# Altium schematic sheet S7CAS-PLEIADES-CARTE-MERE_P07_FPGA_0.SchDoc(S7CAS-PLEIADES-CARTE-MERE_P07_FPGA_0)
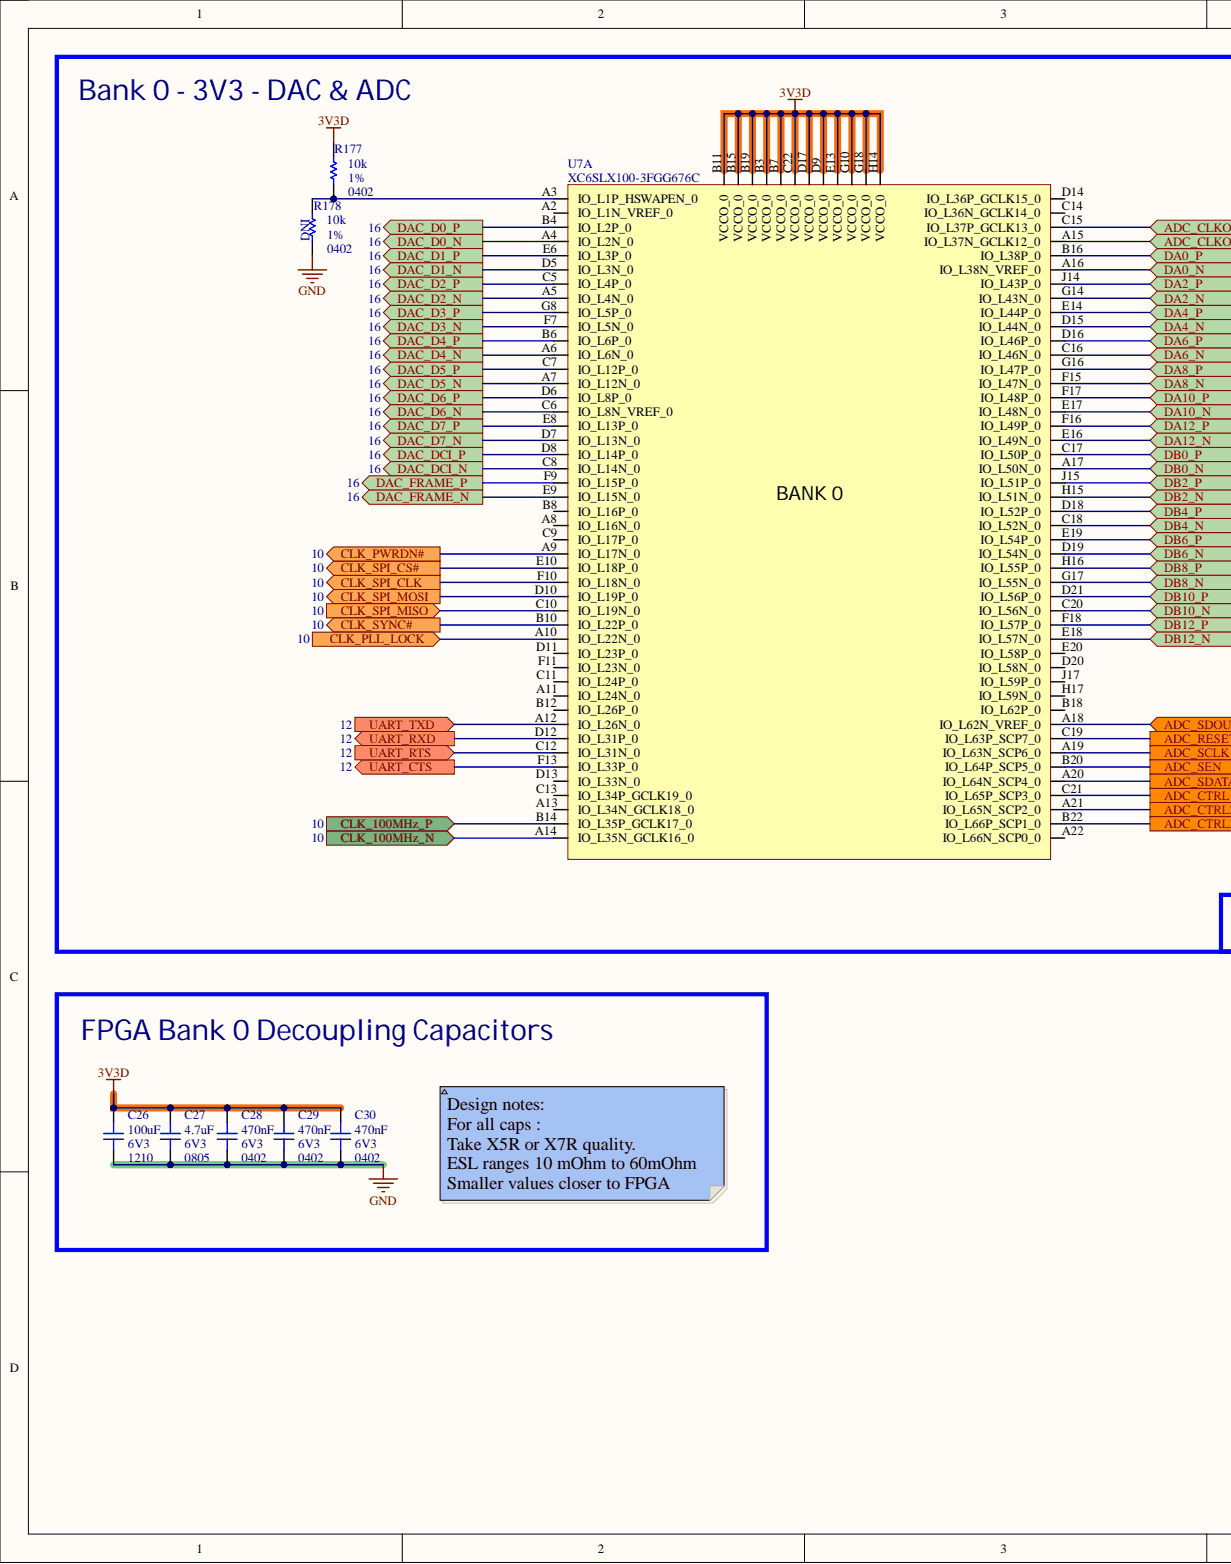
[diagram p0: S7CAS-PLEIADES-CARTE-MERE_P07_FPGA_0.SchDoc, left]
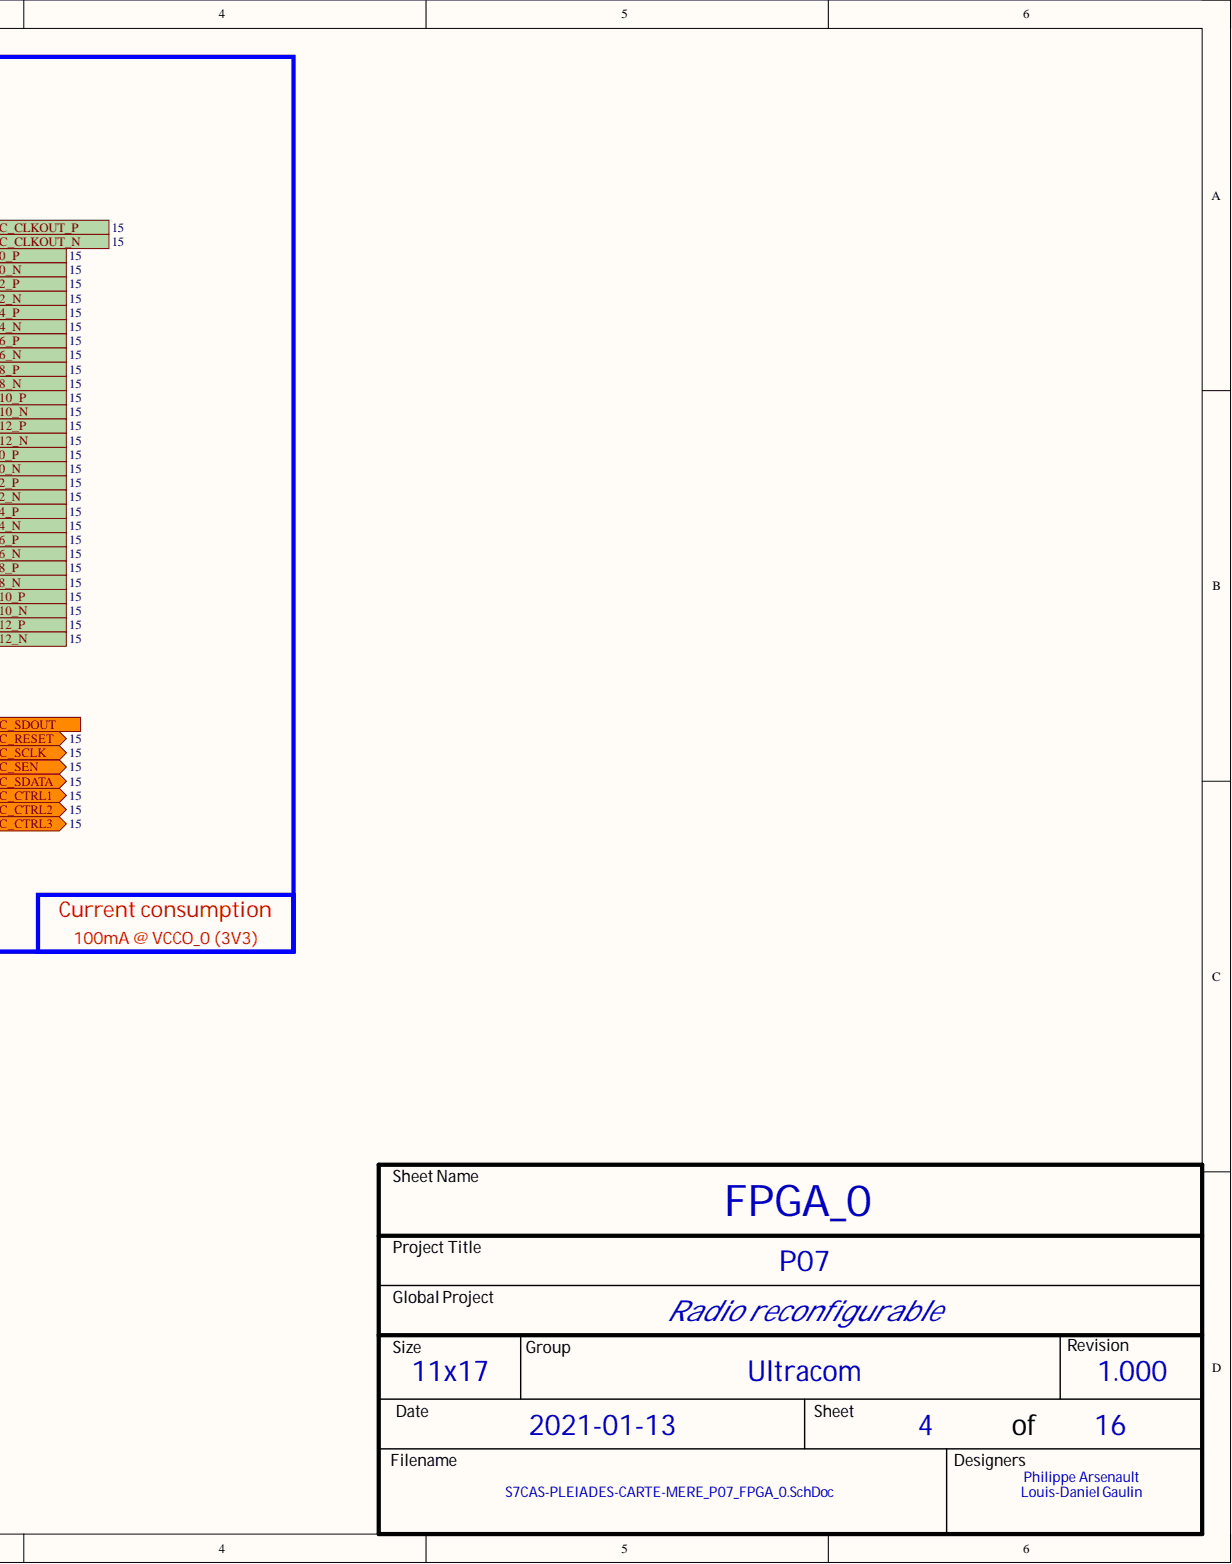
[diagram p1: S7CAS-PLEIADES-CARTE-MERE_P07_FPGA_0.SchDoc, right]

## Parts
C26  value 100uF  Package: 1210  Voltage: 6V3  [CAP_1210]  (hidden comment: CAP - 1210)
C27  value 4.7uF  Package: 0805  Voltage: 6V3  [CAP_0805]  (hidden comment: CAP - 0805)
C28  value 470nF  Package: 0402  Voltage: 6V3  [CAP_0402]  (hidden comment: CAP - 0402)
C29  value 470nF  Package: 0402  Voltage: 6V3  [CAP_0402]  (hidden comment: CAP - 0402)
C30  value 470nF  Package: 0402  Voltage: 6V3  [CAP_0402]  (hidden comment: CAP - 0402)
R177  value 10k  Package: 0402  Precision: 1%  [RES_0402]  (hidden comment: RES - 0402)
R178  value 10k  NI: DNI  Package: 0402  Precision: 1%  [RES_0402]  (hidden comment: RES - 0402)
U7  XC6SLX100-3FGG676C  [FPGA_XILINX_FGG676]  (part A)

## Ports
ADC_CLKOUT_N, ADC_CLKOUT_P, ADC_CTRL1, ADC_CTRL2, ADC_CTRL3, ADC_RESET, ADC_SCLK, ADC_SDATA, ADC_SDOUT, ADC_SEN, CLK_100MHz_N, CLK_100MHz_P, CLK_PLL_LOCK, CLK_PWRDN#, CLK_SPI_CLK, CLK_SPI_CS#, CLK_SPI_MISO, CLK_SPI_MOSI, CLK_SYNC#, DA0_N, DA0_P, DA2_N, DA2_P, DA4_N, DA4_P, DA6_N, DA6_P, DA8_N, DA8_P, DA10_N, DA10_P, DA12_N, DA12_P, DAC_D0_N, DAC_D0_P, DAC_D1_N, DAC_D1_P, DAC_D2_N, DAC_D2_P, DAC_D3_N, DAC_D3_P, DAC_D4_N, DAC_D4_P, DAC_D5_N, DAC_D5_P, DAC_D6_N, DAC_D6_P, DAC_D7_N, DAC_D7_P, DAC_DCI_N, DAC_DCI_P, DAC_FRAME_N, DAC_FRAME_P, DB0_N, DB0_P, DB2_N, DB2_P, DB4_N, DB4_P, DB6_N, DB6_P, DB8_N, DB8_P, DB10_N, DB10_P, DB12_N, DB12_P, UART_CTS, UART_RTS, UART_RXD, UART_TXD

## Nets
3V3D: C26.2 C27.2 C28.2 C29.2 C30.2 R177.2 U7.B3(VCCO_0) U7.B7(VCCO_0) U7.B11(VCCO_0) U7.B15(VCCO_0) U7.B19(VCCO_0) U7.C22(VCCO_0) U7.D9(VCCO_0) U7.D17(VCCO_0) U7.E13(VCCO_0) U7.G10(VCCO_0) U7.G18(VCCO_0) U7.H14(VCCO_0)
GND: C26.1 C27.1 C28.1 C29.1 C30.1 R178.1
ADC_CLKOUT_N: U7.A15(IO_L37N_GCLK12_0) ; port ADC_CLKOUT_N
ADC_CLKOUT_P: U7.C15(IO_L37P_GCLK13_0) ; port ADC_CLKOUT_P
ADC_CTRL1: U7.C21(IO_L65P_SCP3_0) ; port ADC_CTRL1
ADC_CTRL2: U7.A21(IO_L65N_SCP2_0) ; port ADC_CTRL2
ADC_CTRL3: U7.B22(IO_L66P_SCP1_0) ; port ADC_CTRL3
ADC_RESET: U7.C19(IO_L63P_SCP7_0) ; port ADC_RESET
ADC_SCLK: U7.A19(IO_L63N_SCP6_0) ; port ADC_SCLK
ADC_SDATA: U7.A20(IO_L64N_SCP4_0) ; port ADC_SDATA
ADC_SDOUT: U7.A18(IO_L62N_VREF_0) ; port ADC_SDOUT
ADC_SEN: U7.B20(IO_L64P_SCP5_0) ; port ADC_SEN
CLK_100MHz_N: U7.A14(IO_L35N_GCLK16_0) ; port CLK_100MHz_N
CLK_100MHz_P: U7.B14(IO_L35P_GCLK17_0) ; port CLK_100MHz_P
CLK_PLL_LOCK: U7.A10(IO_L22N_0) ; port CLK_PLL_LOCK
CLK_PWRDN#: U7.A9(IO_L17N_0) ; port CLK_PWRDN#
CLK_SPI_CLK: U7.F10(IO_L18N_0) ; port CLK_SPI_CLK
CLK_SPI_CS#: U7.E10(IO_L18P_0) ; port CLK_SPI_CS#
CLK_SPI_MISO: U7.C10(IO_L19N_0) ; port CLK_SPI_MISO
CLK_SPI_MOSI: U7.D10(IO_L19P_0) ; port CLK_SPI_MOSI
CLK_SYNC#: U7.B10(IO_L22P_0) ; port CLK_SYNC#
DA0_N: U7.A16(IO_L38N_VREF_0) ; port DA0_N
DA0_P: U7.B16(IO_L38P_0) ; port DA0_P
DA2_N: U7.G14(IO_L43N_0) ; port DA2_N
DA2_P: U7.J14(IO_L43P_0) ; port DA2_P
DA4_N: U7.D15(IO_L44N_0) ; port DA4_N
DA4_P: U7.E14(IO_L44P_0) ; port DA4_P
DA6_N: U7.C16(IO_L46N_0) ; port DA6_N
DA6_P: U7.D16(IO_L46P_0) ; port DA6_P
DA8_N: U7.F15(IO_L47N_0) ; port DA8_N
DA8_P: U7.G16(IO_L47P_0) ; port DA8_P
DA10_N: U7.E17(IO_L48N_0) ; port DA10_N
DA10_P: U7.F17(IO_L48P_0) ; port DA10_P
DA12_N: U7.E16(IO_L49N_0) ; port DA12_N
DA12_P: U7.F16(IO_L49P_0) ; port DA12_P
DAC_D0_N: U7.A4(IO_L2N_0) ; port DAC_D0_N
DAC_D0_P: U7.B4(IO_L2P_0) ; port DAC_D0_P
DAC_D1_N: U7.D5(IO_L3N_0) ; port DAC_D1_N
DAC_D1_P: U7.E6(IO_L3P_0) ; port DAC_D1_P
DAC_D2_N: U7.A5(IO_L4N_0) ; port DAC_D2_N
DAC_D2_P: U7.C5(IO_L4P_0) ; port DAC_D2_P
DAC_D3_N: U7.F7(IO_L5N_0) ; port DAC_D3_N
DAC_D3_P: U7.G8(IO_L5P_0) ; port DAC_D3_P
DAC_D4_N: U7.A6(IO_L6N_0) ; port DAC_D4_N
DAC_D4_P: U7.B6(IO_L6P_0) ; port DAC_D4_P
DAC_D5_N: U7.A7(IO_L12N_0) ; port DAC_D5_N
DAC_D5_P: U7.C7(IO_L12P_0) ; port DAC_D5_P
DAC_D6_N: U7.C6(IO_L8N_VREF_0) ; port DAC_D6_N
DAC_D6_P: U7.D6(IO_L8P_0) ; port DAC_D6_P
DAC_D7_N: U7.D7(IO_L13N_0) ; port DAC_D7_N
DAC_D7_P: U7.E8(IO_L13P_0) ; port DAC_D7_P
DAC_DCI_N: U7.C8(IO_L14N_0) ; port DAC_DCI_N
DAC_DCI_P: U7.D8(IO_L14P_0) ; port DAC_DCI_P
DAC_FRAME_N: U7.E9(IO_L15N_0) ; port DAC_FRAME_N
DAC_FRAME_P: U7.F9(IO_L15P_0) ; port DAC_FRAME_P
DB0_N: U7.A17(IO_L50N_0) ; port DB0_N
DB0_P: U7.C17(IO_L50P_0) ; port DB0_P
DB2_N: U7.H15(IO_L51N_0) ; port DB2_N
DB2_P: U7.J15(IO_L51P_0) ; port DB2_P
DB4_N: U7.C18(IO_L52N_0) ; port DB4_N
DB4_P: U7.D18(IO_L52P_0) ; port DB4_P
DB6_N: U7.D19(IO_L54N_0) ; port DB6_N
DB6_P: U7.E19(IO_L54P_0) ; port DB6_P
DB8_N: U7.G17(IO_L55N_0) ; port DB8_N
DB8_P: U7.H16(IO_L55P_0) ; port DB8_P
DB10_N: U7.C20(IO_L56N_0) ; port DB10_N
DB10_P: U7.D21(IO_L56P_0) ; port DB10_P
DB12_N: U7.E18(IO_L57N_0) ; port DB12_N
DB12_P: U7.F18(IO_L57P_0) ; port DB12_P
UART_CTS: U7.F13(IO_L33P_0) ; port UART_CTS
UART_RTS: U7.C12(IO_L31N_0) ; port UART_RTS
UART_RXD: U7.D12(IO_L31P_0) ; port UART_RXD
UART_TXD: U7.A12(IO_L26N_0) ; port UART_TXD
NetR177_1: R177.1 R178.2 U7.A3(IO_L1P_HSWAPEN_0)

Not connected: U7 A2(IO_L1N_VREF_0) A8(IO_L16N_0) A11(IO_L24N_0) A13(IO_L34N_GCLK18_0) A22(IO_L66N_SCP0_0) B8(IO_L16P_0) B12(IO_L26P_0) B18(IO_L62P_0) C9(IO_L17P_0) C11(IO_L24P_0) C13(IO_L34P_GCLK19_0) C14(IO_L36N_GCLK14_0) D11(IO_L23P_0) D13(IO_L33N_0) D14(IO_L36P_GCLK15_0) D20(IO_L58N_0) E20(IO_L58P_0) F11(IO_L23N_0) H17(IO_L59N_0) J17(IO_L59P_0)

## Library data of the parts
C26 = CAP - 1210: Precision: 10%; Component Kind: Standard; Description: CAP - 1210; Library Name: S7CAS_LIB2021.IntLib; CreatedBy: Jonathan Bouchard
C27 = CAP - 0805: Precision: 10%; Component Kind: Standard; Description: CAP - 0805; Library Name: S7CAS_LIB2021.IntLib; CreatedBy: Jonathan Bouchard
C28-C30 = CAP - 0402: Precision: 10%; Component Kind: Standard; Description: CAP - 0402; Library Name: S7CAS_LIB2021.IntLib; CreatedBy: Jonathan Bouchard
R177 R178 = RES - 0402: Power: 1/16W; Voltage: 50V; Component Kind: Standard; Description: RES - 0402; Library Name: S7CAS_LIB2021.IntLib; CreatedBy: Jonathan Bouchard
U7 = FPGA_XC6SLX100-3FGG676C: FPGA - XILINX SPARTAN 6 480 I/O - BGA-676; Voltage: 1.14 V ~ 1.26 V; Component Kind: Standard; HelpURL: https://www.xilinx.com/support/documentation/data_sheets/ds162.pdf; Library Name: S7CAS_LIB2021.IntLib; Mfg: Xilinx; Mfg Part Number: XC6SLX100-3FGG676C; Package: BGA-676; Supplier 1: Digikey; Supplier Part Number 1: 122-1760-ND; Temperature: 0°C ~ 85°C (TJ); CreatedBy: Jonathan Bouchard
